# Altium schematic sheet 03-ece353_carrier.SchDoc
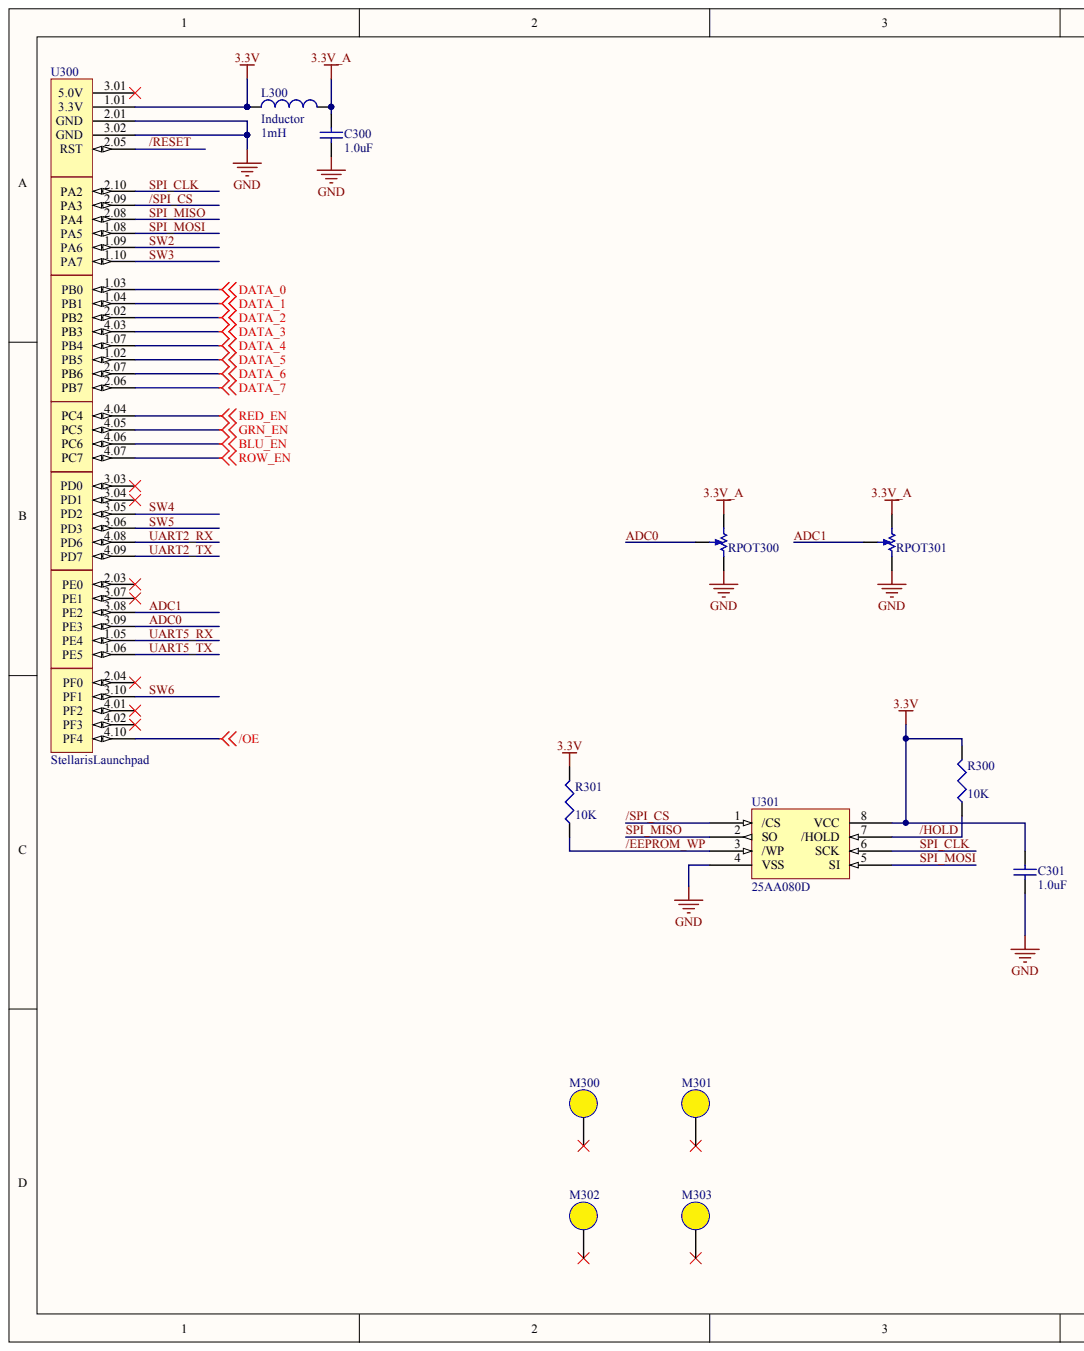
[diagram p0: 03-ece353_carrier.SchDoc, left]
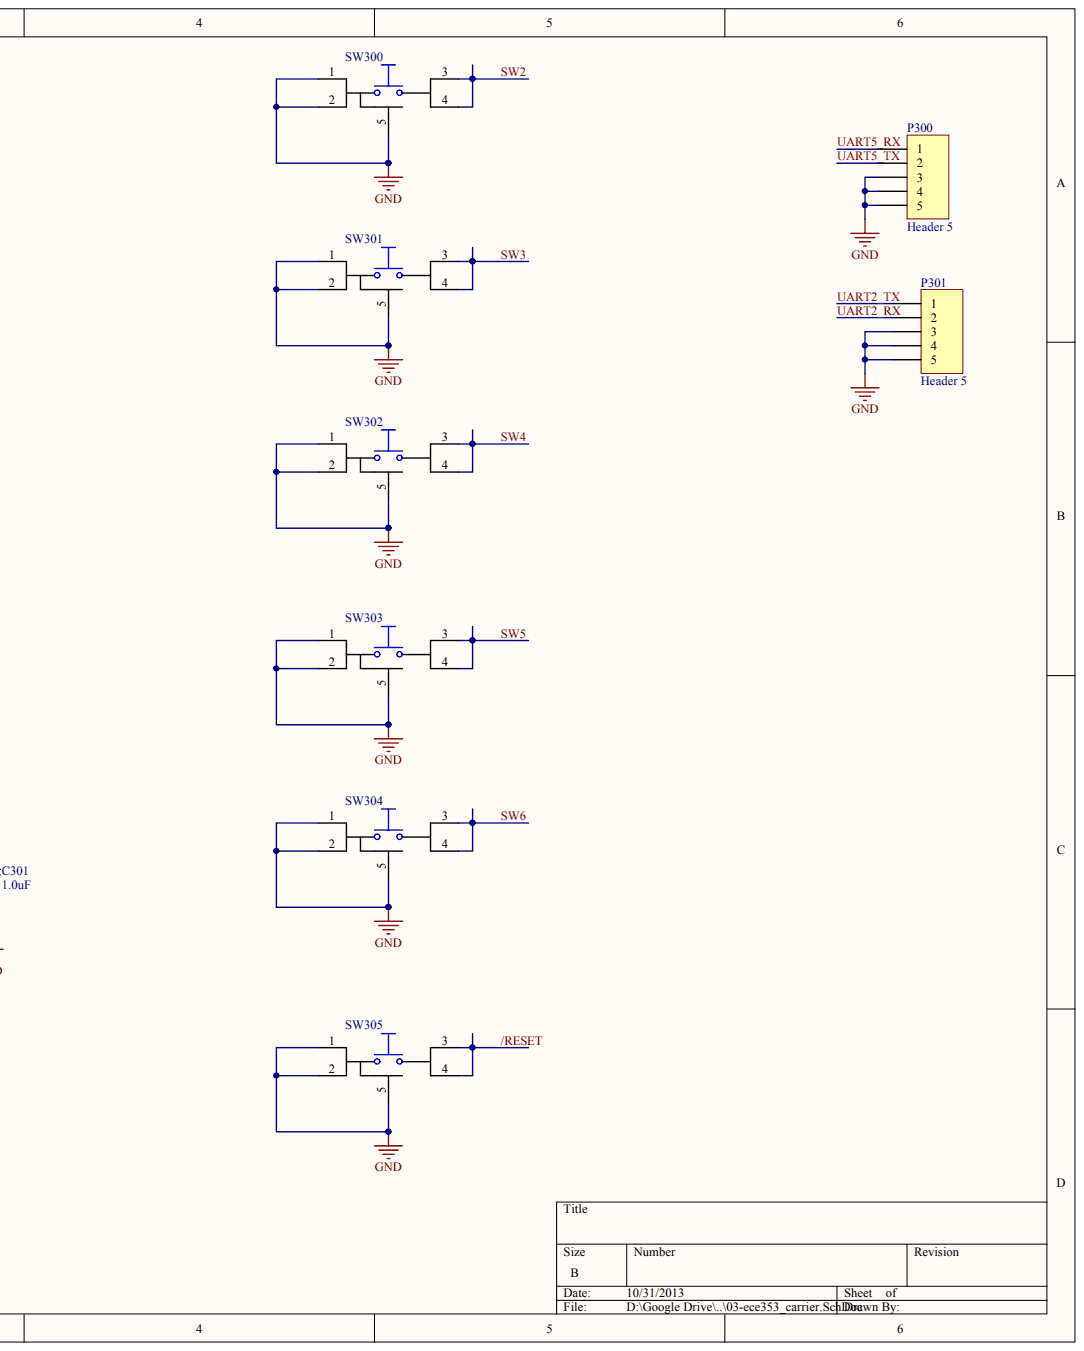
[diagram p1: 03-ece353_carrier.SchDoc, right]

## Parts
C300  value 1.0uF  (hidden comment: CAP_0805_1P00UF)
C301  value 1.0uF  (hidden comment: CAP_0805_1P00UF)
L300  Inductor  value 1mH
M300  TP_ROUND  (value not drawn)
M301  TP_ROUND  (value not drawn)
M302  TP_ROUND  (value not drawn)
M303  TP_ROUND  (value not drawn)
P300  Header 5
P301  Header 5
R300  value 10K  (hidden comment: Res3)
R301  value 10K  (hidden comment: Res3)
RPOT300  P090S-04F20BR10K  (value not drawn)
RPOT301  P090S-04F20BR10K  (value not drawn)
SW300  TLE1105  (value not drawn)
SW301  TLE1105  (value not drawn)
SW302  TLE1105  (value not drawn)
SW303  TLE1105  (value not drawn)
SW304  TLE1105  (value not drawn)
SW305  TLE1105  (value not drawn)
U300  StellarisLaunchpad
U301  25AA080D

## Nets
3.3V: C301.2 L300.1 R300.2 R301.2 U300.1.01(3.3V) U301.8(VCC)
3.3V_A: C300.2 L300.2 RPOT300.CCW RPOT301.CCW
/OE: U300.4.10(PF4)
BLU_EN: U300.4.06(PC6)
DATA_0: U300.1.03(PB0)
DATA_1: U300.1.04(PB1)
DATA_2: U300.2.02(PB2)
DATA_3: U300.4.03(PB3)
DATA_4: U300.1.07(PB4)
DATA_5: U300.1.02(PB5)
DATA_6: U300.2.07(PB6)
DATA_7: U300.2.06(PB7)
GND: C300.1 C301.1 P300.3 P300.4 P300.5 P301.3 P301.4 P301.5 RPOT300.CW RPOT301.CW SW300.1 SW300.2 SW300.5 SW301.1 SW301.2 SW301.5 SW302.1 SW302.2 SW302.5 SW303.1 SW303.2 SW303.5 SW304.1 SW304.2 SW304.5 SW305.1 SW305.2 SW305.5 U300.2.01(GND) U300.3.02(GND) U301.4(VSS)
GRN_EN: U300.4.05(PC5)
RED_EN: U300.4.04(PC4)
ROW_EN: U300.4.07(PC7)
/EEPROM_WP: R301.1 U301.3(/WP)
/HOLD: R300.1 U301.7(/HOLD)
/RESET: SW305.3 SW305.4 U300.2.05(RST)
/SPI_CS: U300.2.09(PA3) U301.1(/CS)
ADC0: RPOT300.W U300.3.09(PE3)
ADC1: RPOT301.W U300.3.08(PE2)
SPI_CLK: U300.2.10(PA2) U301.6(SCK)
SPI_MISO: U300.2.08(PA4) U301.2(SO)
SPI_MOSI: U300.1.08(PA5) U301.5(SI)
SW2: SW300.3 SW300.4 U300.1.09(PA6)
SW3: SW301.3 SW301.4 U300.1.10(PA7)
SW4: SW302.3 SW302.4 U300.3.05(PD2)
SW5: SW303.3 SW303.4 U300.3.06(PD3)
SW6: SW304.3 SW304.4 U300.3.10(PF1)
UART2_RX: P301.2 U300.4.08(PD6)
UART2_TX: P301.1 U300.4.09(PD7)
UART5_RX: P300.1 U300.1.05(PE4)
UART5_TX: P300.2 U300.1.06(PE5)

Not connected: M300 1; M301 1; M302 1; M303 1; U300 2.03(PE0) 2.04(PF0) 3.01(5.0V) 3.03(PD0) 3.04(PD1) 3.07(PE1) 4.01(PF2) 4.02(PF3)

## Library data of the parts
L300 = (no symbol name): Manufacturer: Panasonic Electronic Components; Manufacturer Part Number: ELL-6UH102M; Code_IEC: 1005; Tolerance: ±20%; Current: 180mA; Frequency - Test: 100kHz; Shielding: Shielded; DC Resistance (DCR): 3.67 Ohm; Published: 8-Jun-2000; LatestRevisionDate: 29-May-2009; LatestRevisionNote: IPC-7351 Footprint Added.; PackageReference: 0402-A; PackageDescription: Chip Inductor; Code_JEITA: 0402; Inductance: 1mH; Supplier: Digi-Key; Supplier Part Number: PCD2131TR-ND; RoHS: RoHS Compliant; Category: Inductors, Coils, Chokes; Current - Saturation: -; Current - Temperature Rise: -; Type: -; Frequency - Self Resonant: -; Material - Core: -; Package / Case: 0.251" L x 0.236" W x 0.196" H (6.40mm x 6.00mm x 5.00mm); Mounting Type: Surface Mount; Packaging: Tape & Reel (TR); Operating Temperature: -; Q @ Freq: -; Applications: General Purpose; Publisher: Altium Limited
P300 = (no symbol name): Manufacturer: 3M; Manufacturer Part Number: 961105-5604-AR; LatestRevisionDate: 17-Jul-2002; LatestRevisionNote: Re-released for DXP Platform.; Supplier Part Number: 62R7046; Supplier: Newark; Publisher: Altium Limited
P301 = (no symbol name): Manufacturer: Sullins Connector Solutions; Manufacturer Part Number: PPTC051LGBN-RC; LatestRevisionDate: 17-Jul-2002; LatestRevisionNote: Re-released for DXP Platform.; Supplier: Digi-Key; Supplier Part Number: S5441-ND; Publisher: Altium Limited
R300 R301 = (no symbol name): Manufacturer: VISHAY DALE; Manufacturer Part Number: CRCW080510K0FKEA; Published: 8-Jun-2000; LatestRevisionDate: 29-May-2009; LatestRevisionNote: IPC-7351 Footprint Added.; PackageReference: J1-0603; PackageDescription: Chip Resistor; Supplier: Newark; Supplier Part Number: 52K9795; Publisher: Altium Limited
RPOT300 RPOT301 = (no symbol name): Manufacturer: TT Electronics/BI; Manufacturer Part Number: P090S-04F20BR10K; Supplier Part Number: 987-1276-ND; Supplier: Digi-Key
SW300-SW305 = (no symbol name): Manufacturer Part Number: TLE1105AF160Q; Manufacturer: E-Switch; Supplier Part Number: EG2491-ND; Supplier: Digi-Key
U301 = (no symbol name): Manufacturer: Microchip Technology; Manufacturer Part Number: 25AA080D-I/SN; Speed: 3MHZ, 5MHZ, 10MHZ; Format - Memory: EEPROMs - Serial; Memory Type: EEPROM; Memory Size: 8K (1K x 8); Voltage - Supply: 1.8 V ~ 5.5 V; Interface: SPI, 3-Wire Serial; RoHS: RoHS Compliant; Category: Integrated Circuits (ICs); Supplier: Digi-Key; Packaging: Tube; Supplier Device Package: 8-SOICN; Operating Temperature: -40°C ~ 85°C; Package / Case: 8-SOIC (0.154", 3.90mm Width); Supplier Part Number: 25AA080D-I/SN-ND; Supplier 1: Newark; Supplier Part Number 1: 30H1577
C300 C301 M300-M303 U300 = (no symbol name)
  C300 C301: Supplier 1: Newark; Supplier Part Number 1: 96M1389
